# Altium schematic sheet schema2.SchDoc(schema2)
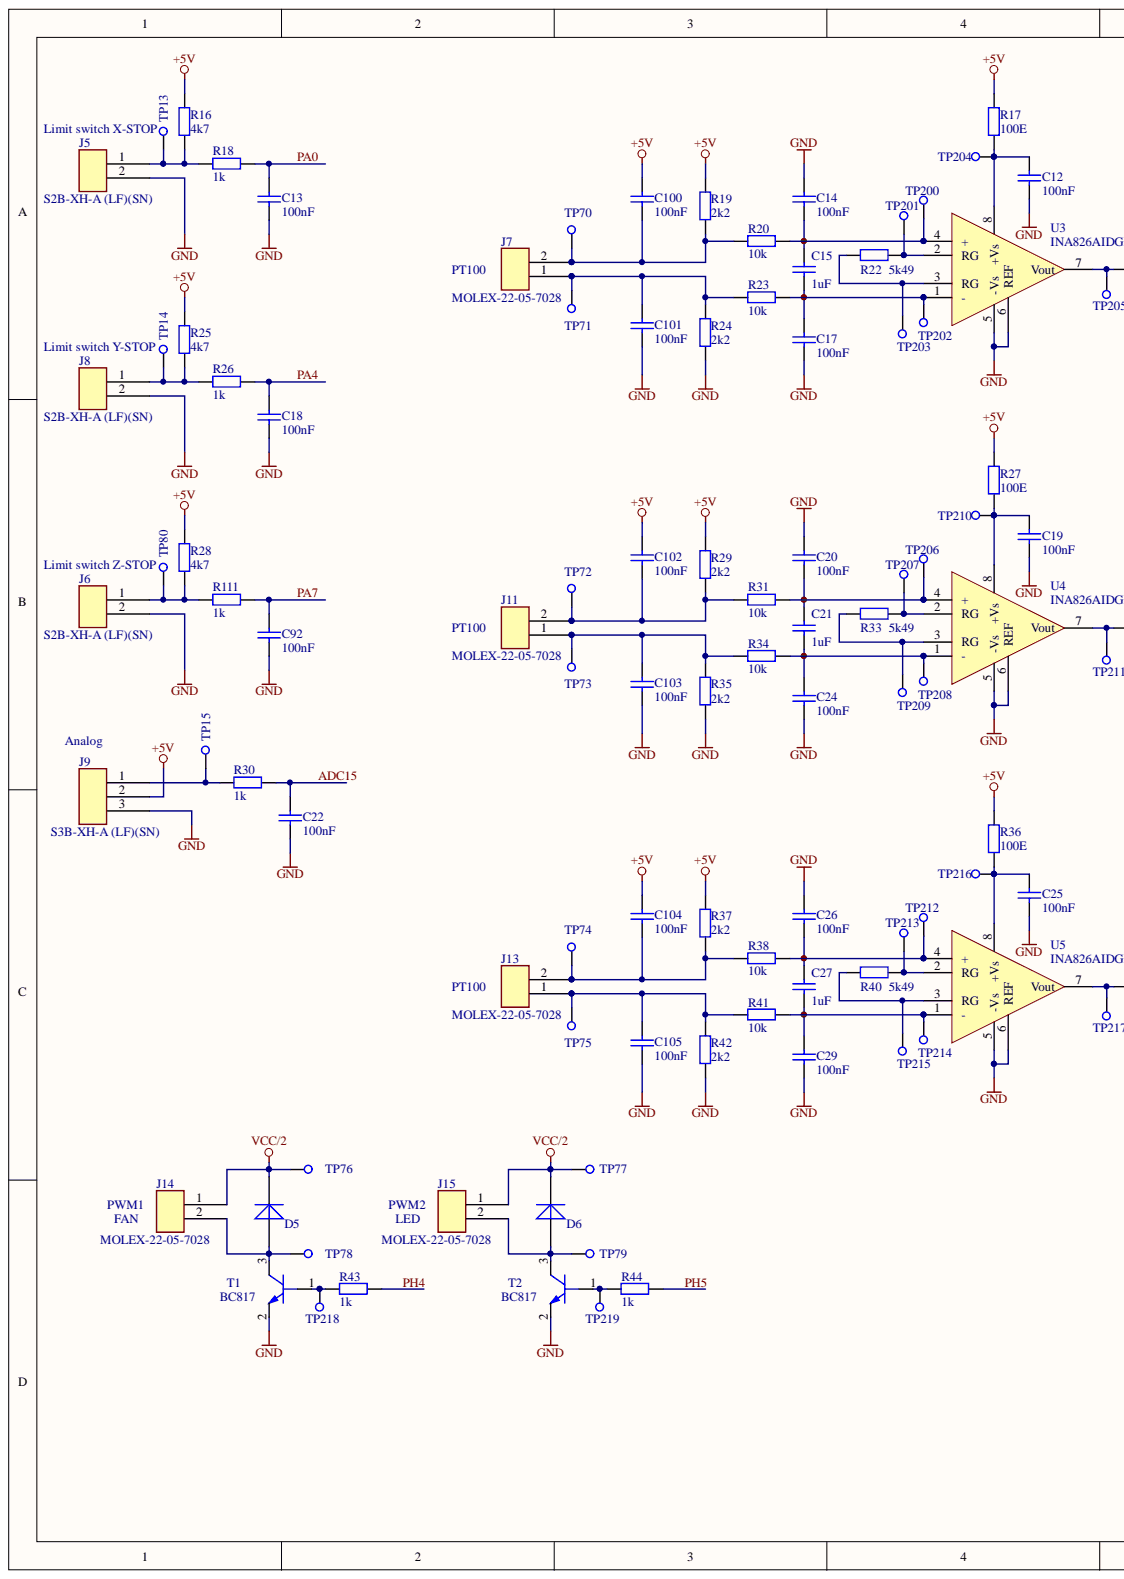
[diagram p0: schema2.SchDoc, left]
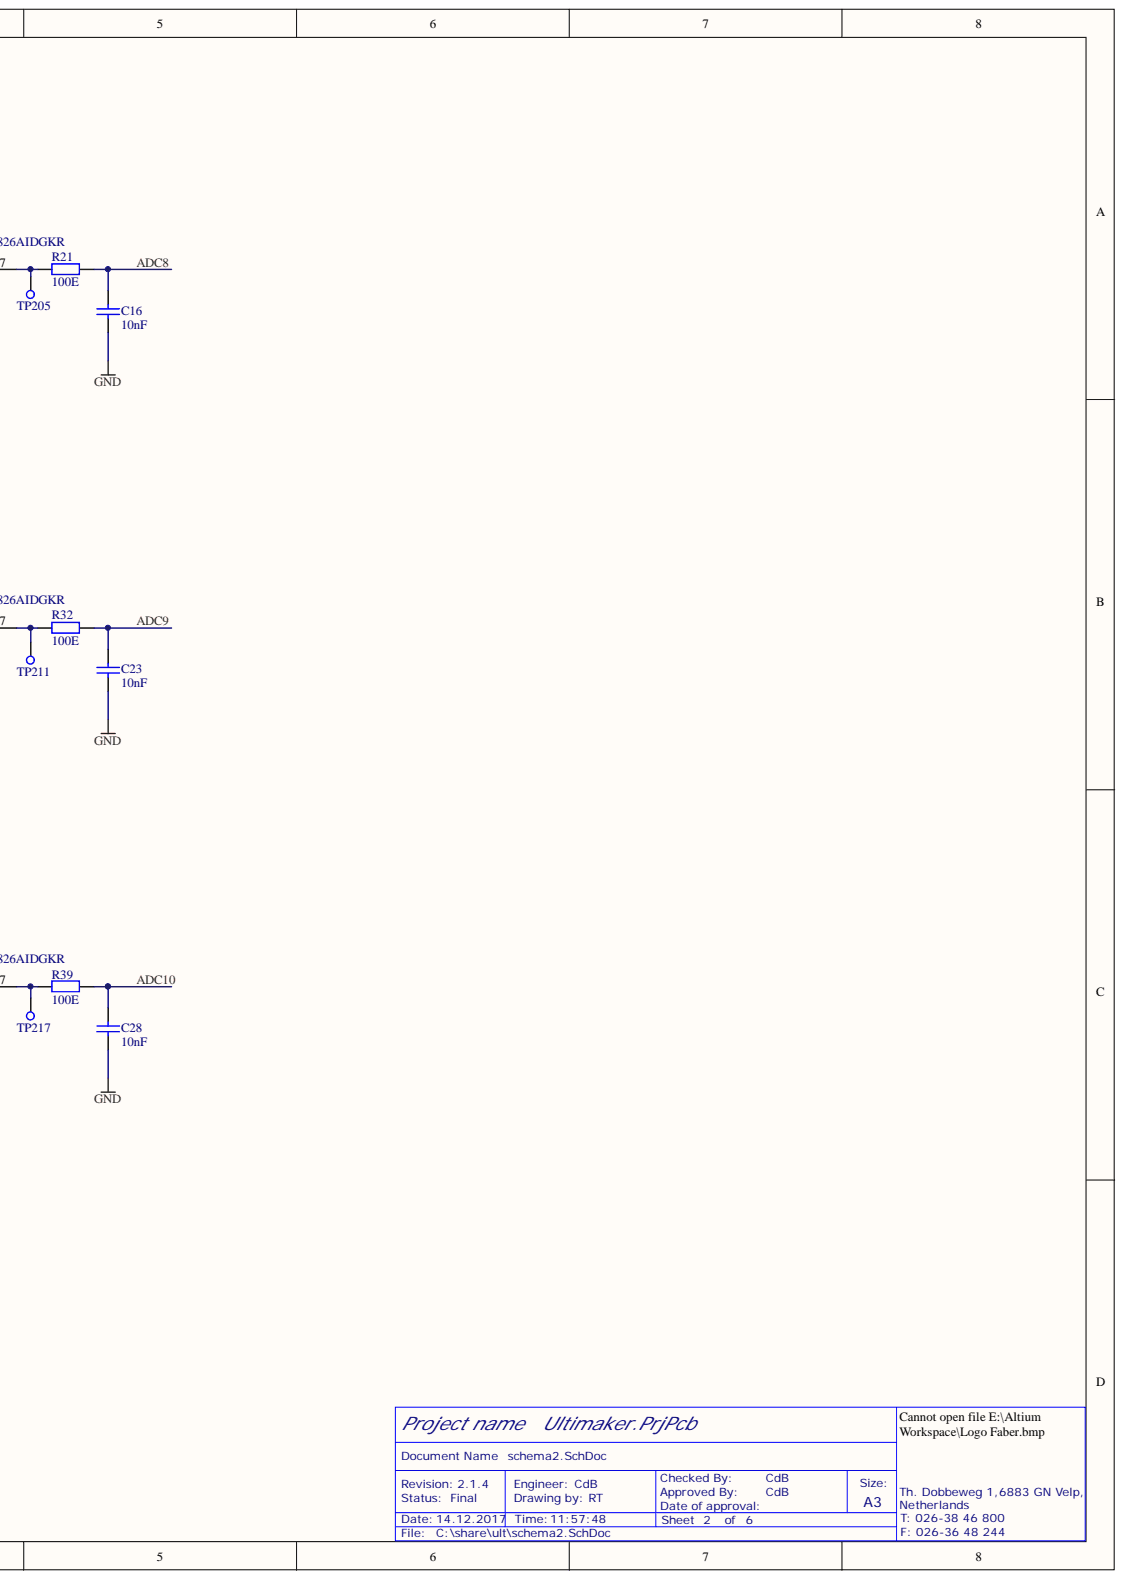
[diagram p1: schema2.SchDoc, right]

## Parts
C12  100nF  [C0603]
C13  100nF  [C0603]
C14  100nF  [C0603]
C15  1uF  [C0603]
C16  10nF  [C0603]
C17  100nF  [C0603]
C18  100nF  [C0603]
C19  100nF  [C0603]
C20  100nF  [C0603]
C21  1uF  [C0603]
C22  100nF  [C0603]
C23  10nF  [C0603]
C24  100nF  [C0603]
C25  100nF  [C0603]
C26  100nF  [C0603]
C27  1uF  [C0603]
C28  10nF  [C0603]
C29  100nF  [C0603]
C92  100nF  [C0603]
C100  100nF  [C0603]
C101  100nF  [C0603]
C102  100nF  [C0603]
C103  100nF  [C0603]
C104  100nF  [C0603]
C105  100nF  [C0603]
D5  S1G  [DO214AC (SMA)]  (value not drawn)
D6  S1G  [DO214AC (SMA)]  (value not drawn)
J5  S2B-XH-A (LF)(SN)  [S2B-XH-A (LF)(SN)]
J6  S2B-XH-A (LF)(SN)  [S2B-XH-A (LF)(SN)]
J7  MOLEX-22-05-7028  [MOLEX-22-05-7028]
J8  S2B-XH-A (LF)(SN)  [S2B-XH-A (LF)(SN)]
J9  S3B-XH-A (LF)(SN)  [S3B-XH-A (LF)(SN)]
J11  MOLEX-22-05-7028  [MOLEX-22-05-7028]
J13  MOLEX-22-05-7028  [MOLEX-22-05-7028]
J14  MOLEX-22-05-7028  [MOLEX-22-05-7028]
J15  MOLEX-22-05-7028  [MOLEX-22-05-7028]
R16  4k7  [R0603]
R17  100E  [R0603]
R18  1k  [R0603]
R19  2k2  [R0603]
R20  10k  [R0603]
R21  100E  [R0603]
R22  5k49  [R0603]
R23  10k  [R0603]
R24  2k2  [R0603]
R25  4k7  [R0603]
R26  1k  [R0603]
R27  100E  [R0603]
R28  4k7  [R0603]
R29  2k2  [R0603]
R30  1k  [R0603]
R31  10k  [R0603]
R32  100E  [R0603]
R33  5k49  [R0603]
R34  10k  [R0603]
R35  2k2  [R0603]
R36  100E  [R0603]
R37  2k2  [R0603]
R38  10k  [R0603]
R39  100E  [R0603]
R40  5k49  [R0603]
R41  10k  [R0603]
R42  2k2  [R0603]
R43  1k  [R0603]
R44  1k  [R0603]
R111  1k  [R0603]
T1  BC817  [SOT23]
T2  BC817  [SOT23]
TP13  Testpoint  [Testpoint]  (value not drawn)
TP14  Testpoint  [Testpoint]  (value not drawn)
TP15  Testpoint  [Testpoint]  (value not drawn)
TP70  Testpoint  [Testpoint]  (value not drawn)
TP71  Testpoint  [Testpoint]  (value not drawn)
TP72  Testpoint  [Testpoint]  (value not drawn)
TP73  Testpoint  [Testpoint]  (value not drawn)
TP74  Testpoint  [Testpoint]  (value not drawn)
TP75  Testpoint  [Testpoint]  (value not drawn)
TP76  Testpoint  [Testpoint]  (value not drawn)
TP77  Testpoint  [Testpoint]  (value not drawn)
TP78  Testpoint  [Testpoint]  (value not drawn)
TP79  Testpoint  [Testpoint]  (value not drawn)
TP80  Testpoint  [Testpoint]  (value not drawn)
TP200  Testpoint 1.6mm  [Testpoint 1.6mm]  (value not drawn)
TP201  Testpoint 1.6mm  [Testpoint 1.6mm]  (value not drawn)
TP202  Testpoint 1.6mm  [Testpoint 1.6mm]  (value not drawn)
TP203  Testpoint 1.6mm  [Testpoint 1.6mm]  (value not drawn)
TP204  Testpoint 1.6mm  [Testpoint 1.6mm]  (value not drawn)
TP205  Testpoint 1.6mm  [Testpoint 1.6mm]  (value not drawn)
TP206  Testpoint 1.6mm  [Testpoint 1.6mm]  (value not drawn)
TP207  Testpoint 1.6mm  [Testpoint 1.6mm]  (value not drawn)
TP208  Testpoint 1.6mm  [Testpoint 1.6mm]  (value not drawn)
TP209  Testpoint 1.6mm  [Testpoint 1.6mm]  (value not drawn)
TP210  Testpoint 1.6mm  [Testpoint 1.6mm]  (value not drawn)
TP211  Testpoint 1.6mm  [Testpoint 1.6mm]  (value not drawn)
TP212  Testpoint 1.6mm  [Testpoint 1.6mm]  (value not drawn)
TP213  Testpoint 1.6mm  [Testpoint 1.6mm]  (value not drawn)
TP214  Testpoint 1.6mm  [Testpoint 1.6mm]  (value not drawn)
TP215  Testpoint 1.6mm  [Testpoint 1.6mm]  (value not drawn)
TP216  Testpoint 1.6mm  [Testpoint 1.6mm]  (value not drawn)
TP217  Testpoint 1.6mm  [Testpoint 1.6mm]  (value not drawn)
TP218  Testpoint 1.6mm  [Testpoint 1.6mm]  (value not drawn)
TP219  Testpoint 1.6mm  [Testpoint 1.6mm]  (value not drawn)
U3  INA826AIDGKR  [TSOP65P490X110-8N (MSOP8)]
U4  INA826AIDGKR  [TSOP65P490X110-8N (MSOP8)]
U5  INA826AIDGKR  [TSOP65P490X110-8N (MSOP8)]

## Nets
+5V: C100.2 C102.2 C104.2 J9.2 R16.2 R17.1 R19.1 R25.2 R27.1 R28.2 R29.1 R36.1 R37.1
GND: C12.1 C13.1 C14.2 C16.1 C17.1 C18.1 C19.1 C20.2 C22.1 C23.1 C24.1 C25.1 C26.2 C28.1 C29.1 C92.1 C101.1 C103.1 C105.1 J5.2 J6.2 J8.2 J9.3 R24.1 R35.1 R42.1 T1.2 T2.2 U3.5(-Vs) U3.6(REF) U4.5(-Vs) U4.6(REF) U5.5(-Vs) U5.6(REF)
VCC/2: D5.2 D6.2 J14.1 J15.1 TP76.1 TP77.1
ADC8: C16.2 R21.2
ADC9: C23.2 R32.2
ADC10: C28.2 R39.2
ADC15: C22.2 R30.2
PA0: C13.2 R18.2
PA4: C18.2 R26.2
PA7: C92.2 R111.2
PH4: R43.1
PH5: R44.1
NetC12_2: C12.2 R17.2 TP204.1 U3.8(+Vs)
NetC14_1: C14.1 C15.2 R20.2 TP200.1 U3.4(+)
NetC15_1: C15.1 C17.2 R23.2 TP202.1 U3.1(-)
NetC19_2: C19.2 R27.2 TP210.1 U4.8(+Vs)
NetC20_1: C20.1 C21.2 R31.2 TP206.1 U4.4(+)
NetC21_1: C21.1 C24.2 R34.2 TP208.1 U4.1(-)
NetC25_2: C25.2 R36.2 TP216.1 U5.8(+Vs)
NetC26_1: C26.1 C27.2 R38.2 TP212.1 U5.4(+)
NetC27_1: C27.1 C29.2 R41.2 TP214.1 U5.1(-)
NetC100_1: C100.1 J7.2 R19.2 R20.1 TP70.1
NetC101_2: C101.2 J7.1 R23.1 R24.2 TP71.1
NetC102_1: C102.1 J11.2 R29.2 R31.1 TP72.1
NetC103_2: C103.2 J11.1 R34.1 R35.2 TP73.1
NetC104_1: C104.1 J13.2 R37.2 R38.1 TP74.1
NetC105_2: C105.2 J13.1 R41.1 R42.2 TP75.1
NetD5_1: D5.1 J14.2 T1.3 TP78.1
NetD6_1: D6.1 J15.2 T2.3 TP79.1
NetJ5_1: J5.1 R16.1 R18.1 TP13.1
NetJ6_1: J6.1 R28.1 R111.1 TP80.1
NetJ8_1: J8.1 R25.1 R26.1 TP14.1
NetJ9_1: J9.1 R30.1 TP15.1
NetR21_1: R21.1 TP205.1 U3.7(Vout)
NetR22_1: R22.1 TP201.1 U3.2(RG)
NetR22_2: R22.2 TP203.1 U3.3(RG)
NetR32_1: R32.1 TP211.1 U4.7(Vout)
NetR33_1: R33.1 TP207.1 U4.2(RG)
NetR33_2: R33.2 TP209.1 U4.3(RG)
NetR39_1: R39.1 TP217.1 U5.7(Vout)
NetR40_1: R40.1 TP213.1 U5.2(RG)
NetR40_2: R40.2 TP215.1 U5.3(RG)
NetR43_2: R43.2 T1.1 TP218.1
NetR44_2: R44.2 T2.1 TP219.1

## Library data of the parts
C12-C14 C17-C20 C22 C24-C26 C29 C92 C100-C105 = Library_CAP-0002: RO X7R 100nF 10% 50V 0603; Faber ItemCode: 45068500; Database Table Name: Condensatoren$; Value: 100nF; Library Name: Database_Faber.DbLib; ID: Library_CAP-0002; Component Kind: Standard
C15 C21 C27 = Library_CAP-0006: RO X5R 1uF 10% 10V 0603; Faber ItemCode: 36310600; Database Table Name: Condensatoren$; Value: 1uF; Library Name: Database_Faber.DbLib; ID: Library_CAP-0006; Component Kind: Standard
C16 C23 C28 = Library_CAP-0007: RO X7R 10nF 10% 50V 0603; Faber ItemCode: 36270400; Database Table Name: Condensatoren$; Value: 10nF; Library Name: Database_Faber.DbLib; ID: Library_CAP-0007; Component Kind: Standard
D5 D6 = Library_DIO-0001: RO DIODE S1G DO-214AC; Info: Fast Rectifiers 1A 400V; Faber ItemCode: 32284000; Database Table Name: Diodes$; Value: S1G; Library Name: Database_Faber.DbLib; ID: Library_DIO-0001; Component Kind: Standard
J5 J6 J8 = Library_CON-0004: RO CONN PR 2p HKS S2B-XH-A (LF)(SN) JST; Mfg: JST (JAPAN SOLDERLESS TERMINALS); Faber ItemCode: 36926700; Database Table Name: Connectoren$; Value: S2B-XH-A (LF)(SN); Mfg code: S2B-XH-A (LF)(SN); Library Name: Database_Faber.DbLib; ID: Library_CON-0004; Component Kind: Standard
J7 J11 J13-J15 = Library_CON-0006: RO CONN PR 2p HKS 22-05-7028 MOLEX; Info: 2.54mm Pitch KK® Wire-to-Board Header, Right Angle, with Friction Lock, 2 Circuits; Faber ItemCode: 36926400; Database Table Name: Connectoren$; Value: MOLEX-22-05-7028; Mfg code: 22-05-7028; Mfg: MOLEX; Library Name: Database_Faber.DbLib; ID: Library_CON-0006; Component Kind: Standard
J9 = Library_CON-0020: RO CONN PR 3p HKS S3B-XH-A (LF)(SN) JST; Mfg: JST (JAPAN SOLDERLESS TERMINALS); Faber ItemCode: 36948200; Database Table Name: Connectoren$; Value: S3B-XH-A (LF)(SN); Mfg code: S3B-XH-A (LF)(SN); Library Name: Database_Faber.DbLib; ID: Library_CON-0020; Component Kind: Standard
R16 R25 R28 = Library_RES-0002: RO RES 4k70 1% 0,063W  0603; Info: Resistor; Faber ItemCode: 36257400; Database Table Name: Weerstanden$; Value: 4k7; Library Name: Database_Faber.DbLib; ID: Library_RES-0002; Component Kind: Standard
R17 R21 R27 R32 R36 R39 = Library_RES-0006: RO RES 100E 1% 0,063W  0603; Info: Resistor; Faber ItemCode: 32338800; Database Table Name: Weerstanden$; Value: 100E; Library Name: Database_Faber.DbLib; ID: Library_RES-0006; Component Kind: Standard
R18 R26 R30 R43 R44 R111 = Library_RES-0004: RO RES 1k0 1% 0,1W  0603; Info: Resistor; Faber ItemCode: 34637900; Database Table Name: Weerstanden$; Value: 1k; Library Name: Database_Faber.DbLib; ID: Library_RES-0004; Component Kind: Standard
R19 R24 R29 R35 R37 R42 = Library_RES-0010: RO RES 2k2 0,1% 0,063W 25ppm 0603; Mfg code: CPF0603B2K2E1; Mfg: TE CONNECTIVITY / NEOHM; Info: Resistor; Faber ItemCode: 36926600; Database Table Name: Weerstanden$; Value: 2k2; Library Name: Database_Faber.DbLib; ID: Library_RES-0010; Component Kind: Standard
R20 R23 R31 R34 R38 R41 = Library_RES-0001: RO RES 10k0 1% 0,063W  0603; Info: Resistor; Faber ItemCode: 36084500; Database Table Name: Weerstanden$; Value: 10k; Library Name: Database_Faber.DbLib; ID: Library_RES-0001; Component Kind: Standard
R22 R33 R40 = Library_RES-0011: RO RES 5K49 0,1% 0,063W 25ppm 0603; Mfg code: PCF0603R 5K49B.T1; Mfg: WELWYN; Info: Resistor; Faber ItemCode: 36926200; Database Table Name: Weerstanden$; Value: 5k49; Library Name: Database_Faber.DbLib; ID: Library_RES-0011; Component Kind: Standard
T1 T2 = Library_TRA-0001: RO TRANS BC817-25  SOT-23; Info: 45 V, 500 mA NPN general-purpose transistors; Faber ItemCode: 30828000; Database Table Name: Transistoren$; Value: BC817; Library Name: Database_Faber.DbLib; ID: Library_TRA-0001; Component Kind: Standard
TP13-TP15 TP70-TP80 = Library_MISC-0001: Info: Testpoint, 2mm, round; Database Table Name: Misc$; Value: Testpoint; Mfg code: -; Mfg: -; ID: Library_MISC-0001; Faber ItemCode: -; Description: Testpoint; Component Kind: Standard; Library Name: Database_Faber.DbLib
TP200-TP219 = (no symbol name): ID: Library_MISC-0001; Value: Testpoint 1.6mm; Mfg code: -; Mfg: -; Info: Testpoint 1.6mm; Faber ItemCode: -; Description: Testpoint 1.6mm; Component Kind: Standard; Library Name: Ultimaker.SCHLIB
U3-U5 = Library_ICS-0003: RO IC INA826AIDGKR MSOP-8 TI; Mfg: Texas Instruments; Info: Precision, 200-µA Supply Current, 2.7-V to 36-V Supply Instrumentation Amplifier with Rail-to-Rail Output; Faber ItemCode: 36926300; Database Table Name: ICs$; Value: INA826AIDGKR; Mfg code: INA826AIDGKR; Library Name: Database_Faber.DbLib; ID: Library_ICS-0003; Component Kind: Standard
